# Altium schematic sheet Folio 01.SchDoc
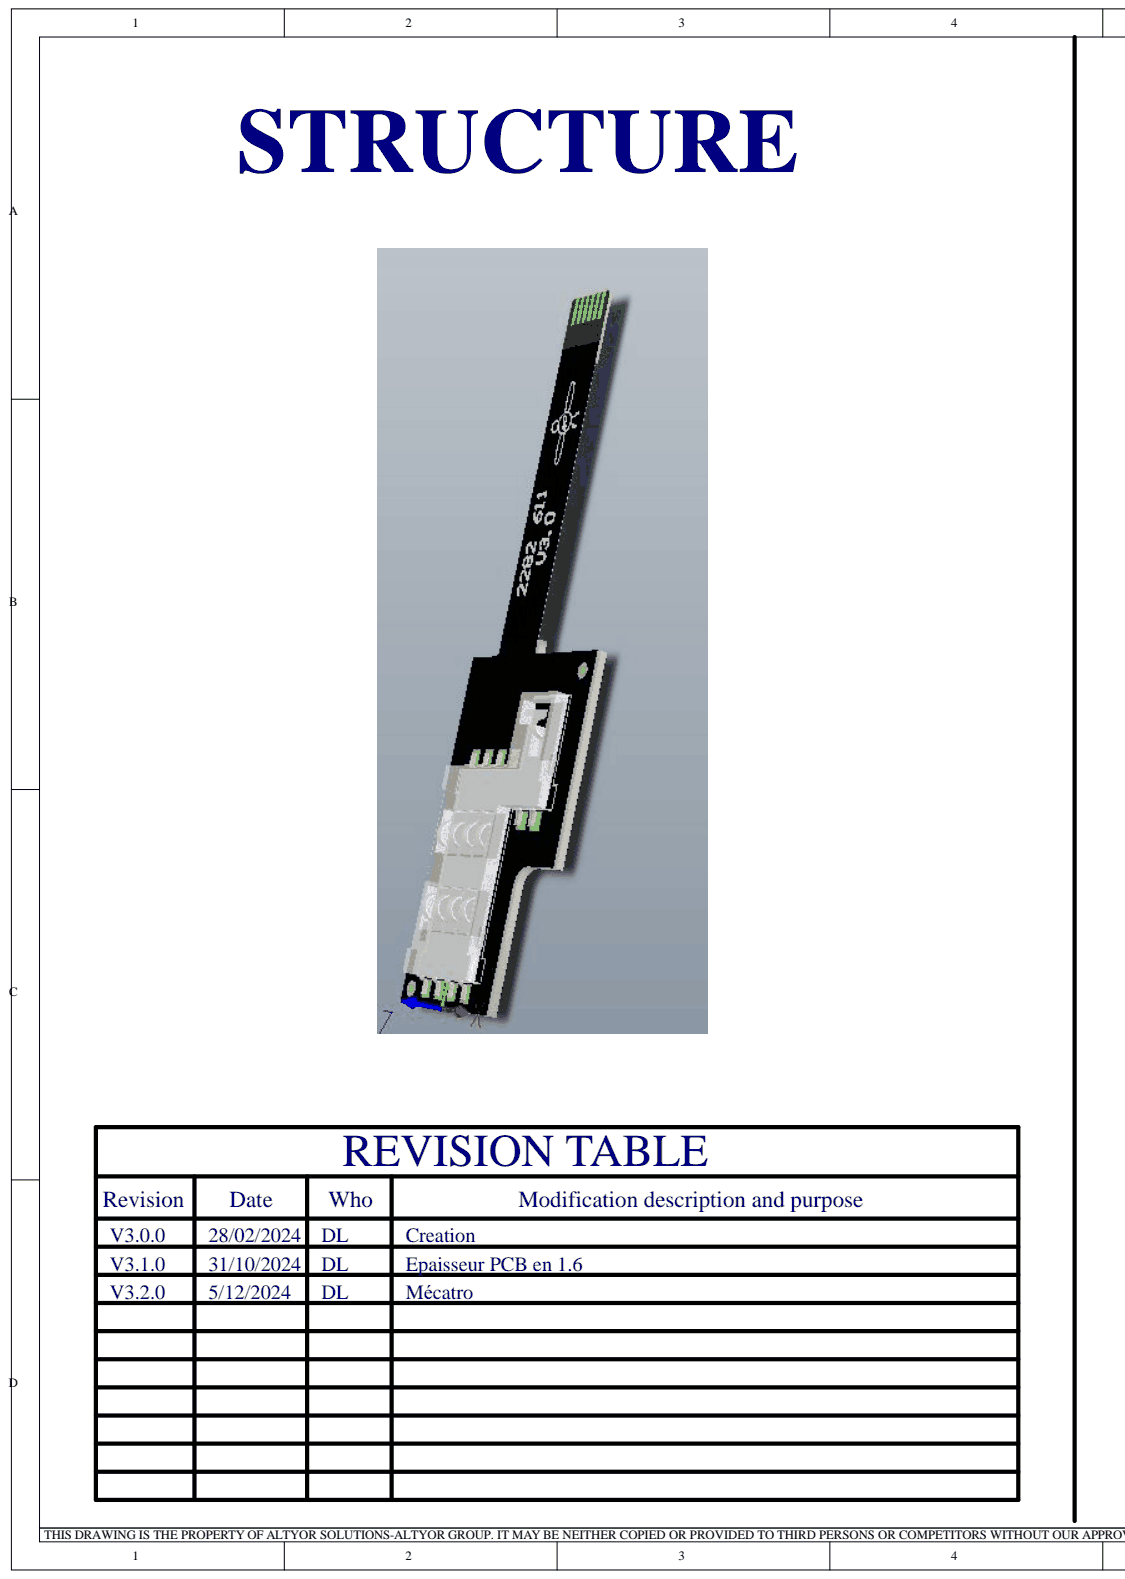
[diagram p0: Folio 01.SchDoc, left]
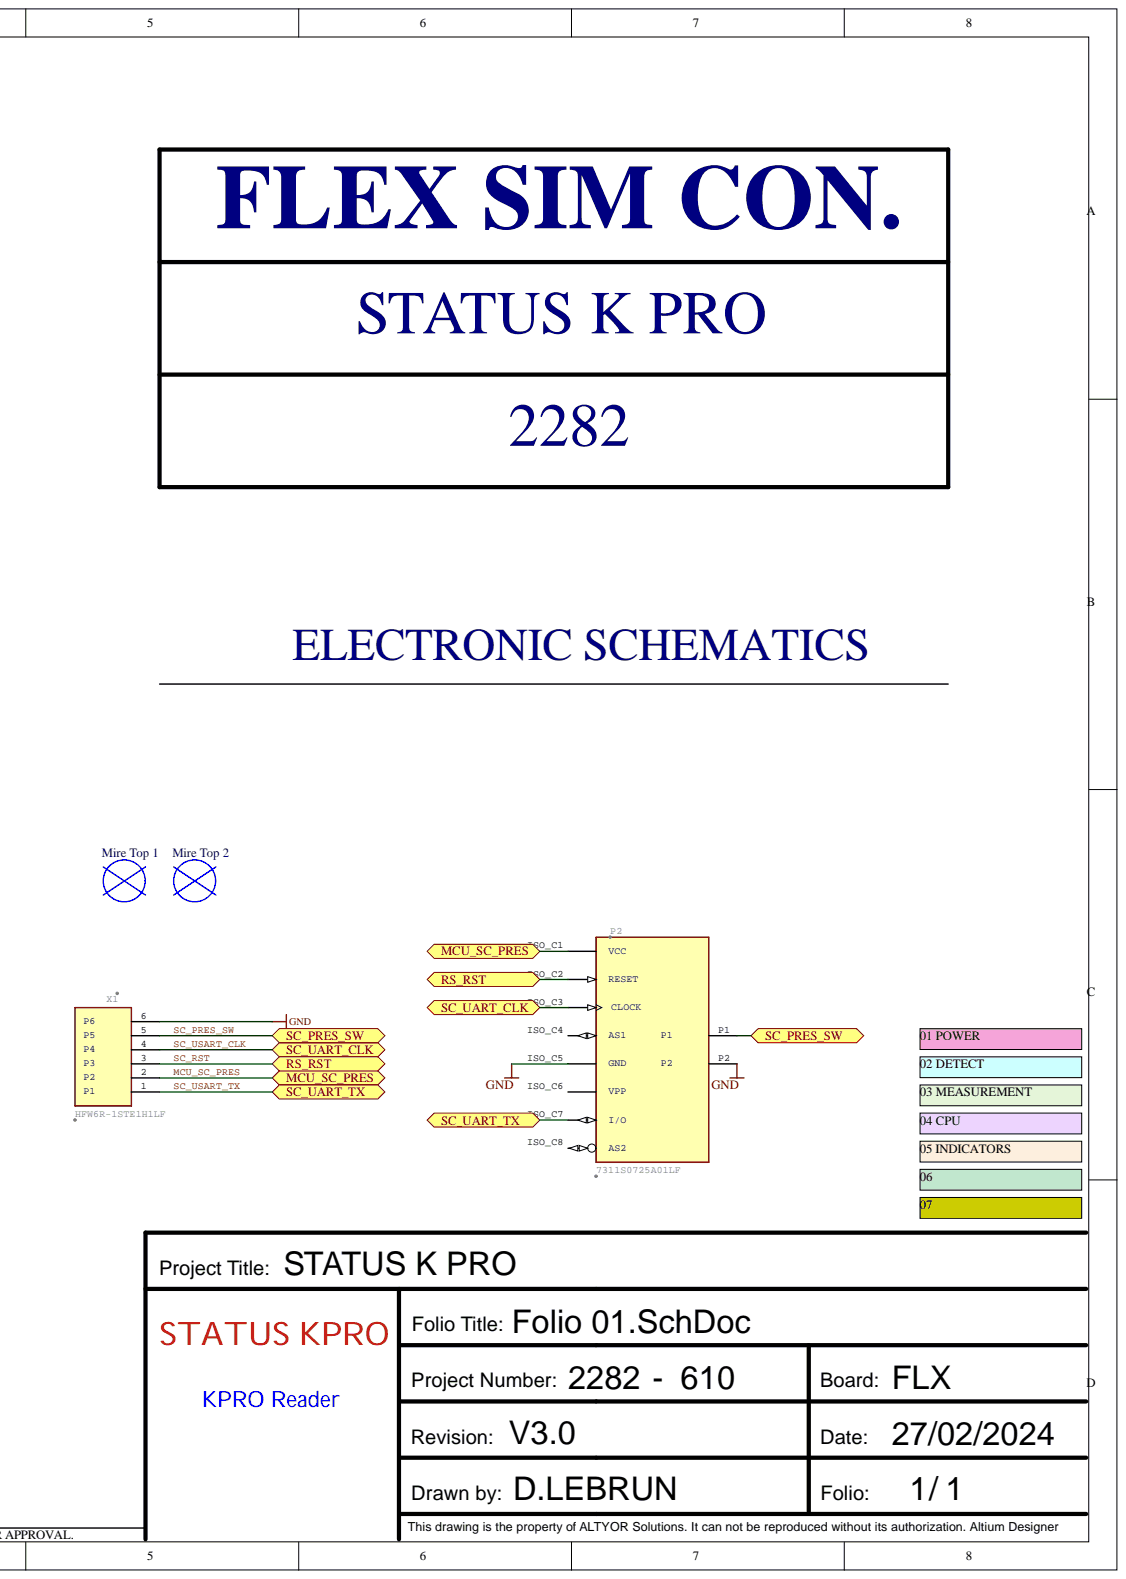
[diagram p1: Folio 01.SchDoc, right]

## Parts
Mire_Top_1  DNI  [MIRE]  (value not drawn)
Mire_Top_2  DNI  [MIRE]  (value not drawn)
P2  7311S0725A01LF  [7311S0725A01LF]
X1  HFW6R-1STE1H1LF  [FPC_HFW6R-1STE1H1LF]  (no BOM)

## Ports
MCU_SC_PRES, RS_RST, SC_PRES_SW, SC_UART_CLK, SC_UART_TX

## Nets
GND: P2.ISO_C5(GND) P2.P2 X1.6
MCU_SC_PRES: P2.ISO_C1(VCC) X1.2 ; port MCU_SC_PRES
SC_PRES_SW: P2.P1 X1.5 ; port SC_PRES_SW
SC_RST: P2.ISO_C2(RESET) X1.3 ; port RS_RST
SC_USART_CLK: P2.ISO_C3(CLOCK) X1.4 ; port SC_UART_CLK
SC_USART_TX: P2.ISO_C7(I/O) X1.1 ; port SC_UART_TX

Not connected: P2 ISO_C4(AS1) ISO_C6(VPP) ISO_C8(AS2)

## Library data of the parts
Mire_Top_1 Mire_Top_2 = Mire: Component Kind: Standard; Description: Mire; NAME: DNI; Library Name: PcbLibPDCi - NonValide.SchLib
P2 = 7311S0725A01LF: 8 Positions connecteur de carte Micro SIM Montage en surface Ã  angle droit Or avec commutateur; MANUFACTURER: AMPHENOL; ARTICLE CODE: MSR 649-7311S0725A01LF; ERP CODE: 10-*; GENERIC: False; NAME: 8P READER; TMAX: 85; TMIN: -40; Component Kind: Standard; Library Name: FLX-2282-601.SchLib
X1 = FPC_HFW6R-1STE1H1LF: Connecteur FCC 6pts 1mm - bottom contect- right angle - 6x1mm; MANUFACTURER: AMPHENOL; ARTICLE CODE: MSR 649-HFW6R-1STE1H1LF; GENERIC: à tester (status im); NAME: FCC 6x1mm; TMAX: 105; TMIN: -55; Library Name: FLX-2282-601.SchLib
